# Altium schematic sheet powerRegulator.SchDoc
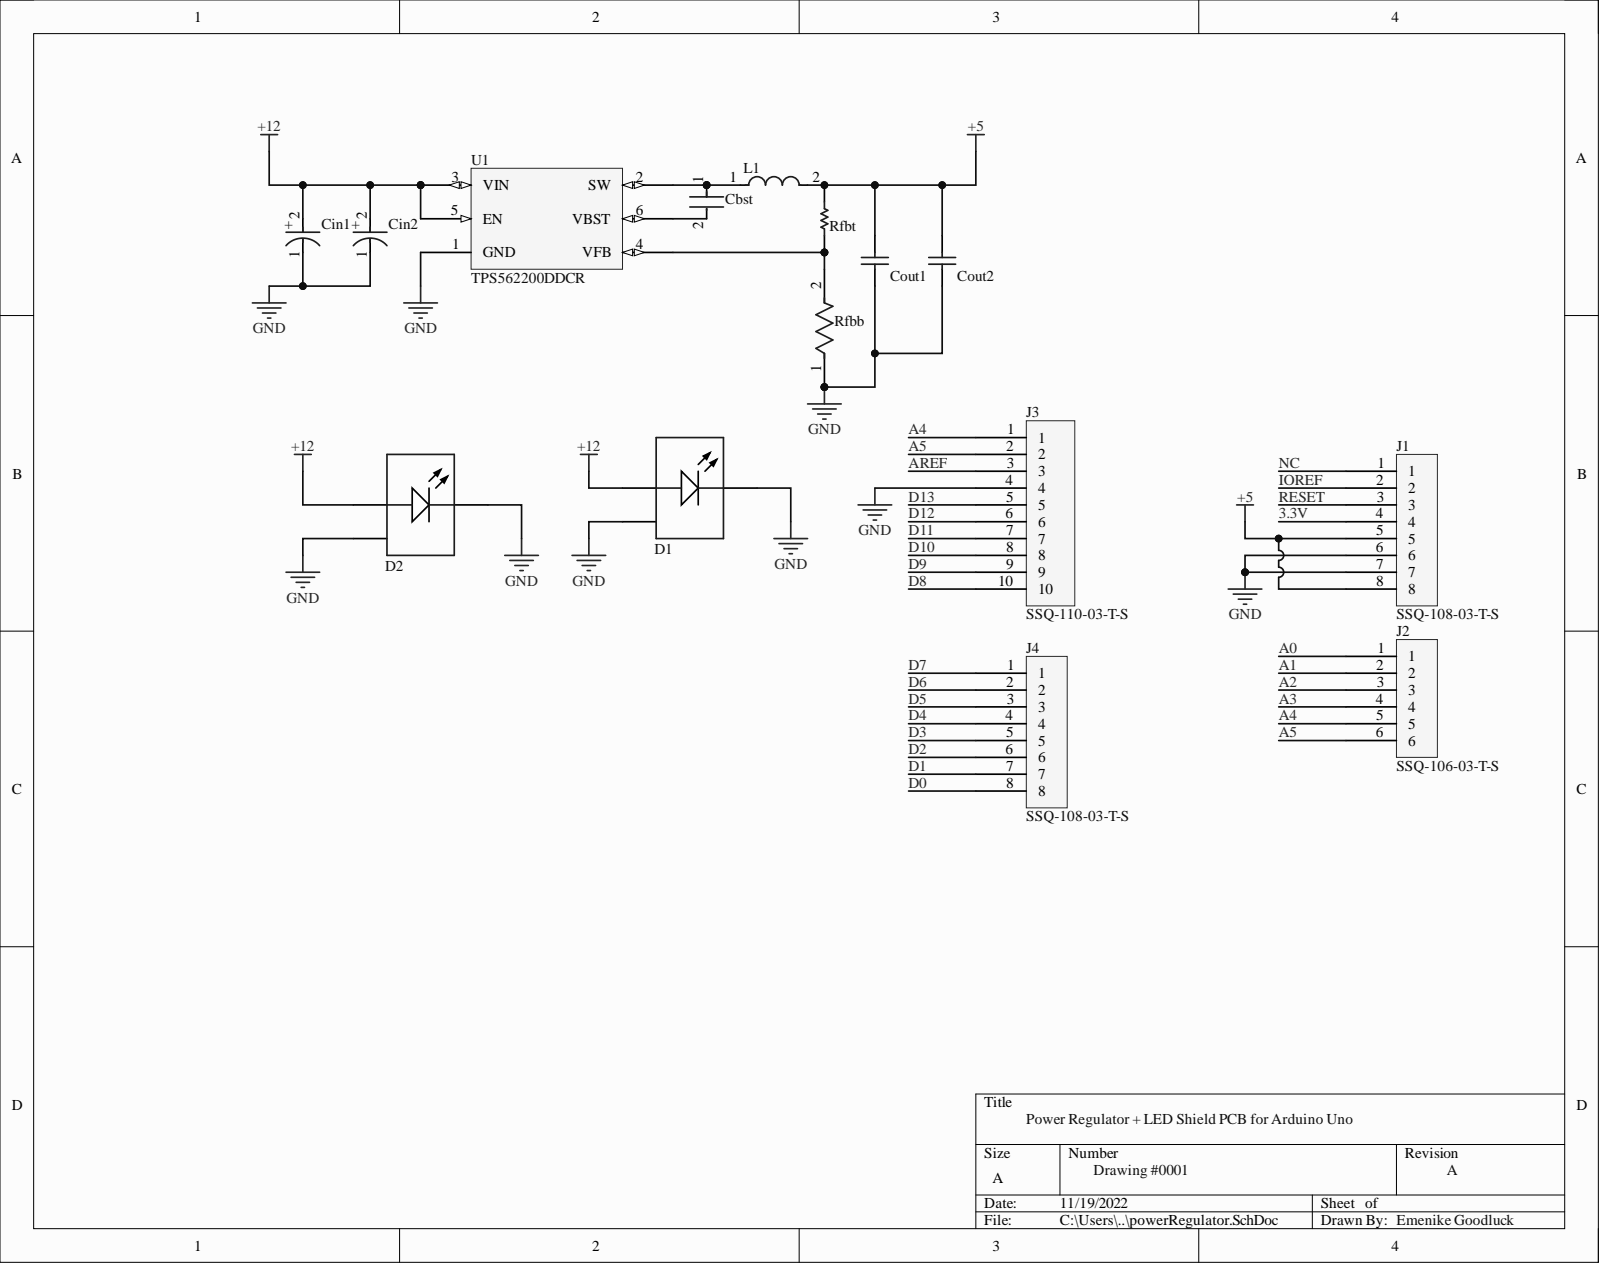
[diagram p0: powerRegulator.SchDoc]

## Parts
Cbst  CC0805KRX7R8BB104  [FP-CC0805-0_85-MFG]  (value not drawn)
Cin1  T491D106K035AT  [FP-T491D106K035AT-MFG]  (value not drawn)
Cin2  T491D106K035AT  [FP-T491D106K035AT-MFG]  (value not drawn)
Cout1  C1608X5R1A226M080AC  [CAPC1608X100X20ML10]  (value not drawn)
Cout2  C1608X5R1A226M080AC  [CAPC1608X100X20ML10]  (value not drawn)
D1  MKRAWT-00-0000-0D0HG235H  [CREE-MK-R-2_V]  (value not drawn)
D2  MKRAWT-00-0000-0D0HG235H  [CREE-MK-R-2_V]  (value not drawn)
J1  SSQ-108-03-T-S  [SSQ-108-03-T-S-Footprint-1]
J2  SSQ-106-03-T-S  [SSQ-108-03-T-S-Footprint-1]
J3  SSQ-110-03-T-S  [SSQ-108-03-T-S-Footprint-1]
J4  SSQ-108-03-T-S  [SSQ-108-03-T-S-Footprint-1]
L1  NR6028T4R7M  [FP-NR6028-MFG]  (value not drawn)
Rfbb  ERJ-1GNF1002C  [FP-ERJ1G-MFG]  (value not drawn)
Rfbt  CRCW020156K2FNED  [RESC0603X28X15NL05T05]  (value not drawn)
U1  TPS562200DDCR  [SOIC127P280X110-6N]

## Nets
+5: Cout1.2 Cout2.2 J1.5 J1.8 L1.2 Rfbt.2
+12: Cin1.2 Cin2.2 D1.1 D2.1 U1.3(VIN) U1.5(EN)
GND: Cin1.1 Cin2.1 Cout1.1 Cout2.1 D1.2 D1.3 D2.2 D2.3 J1.6 J1.7 J3.4 Rfbb.1 U1.1(GND)
3.3V: J1.4
A0: J2.1
A1: J2.2
A2: J2.3
A3: J2.4
A4: J2.5 J3.1
A5: J2.6 J3.2
AREF: J3.3
D0: J4.8
D1: J4.7
D2: J4.6
D3: J4.5
D4: J4.4
D5: J4.3
D6: J4.2
D7: J4.1
D8: J3.10
D9: J3.9
D10: J3.8
D11: J3.7
D12: J3.6
D13: J3.5
IOREF: J1.2
NC: J1.1
RESET: J1.3
NetCbst_1: Cbst.1 L1.1 U1.2(SW)
NetCbst_2: Cbst.2 U1.6(VBST)
NetRfbb_2: Rfbb.2 Rfbt.1 U1.4(VFB)

## Library data of the parts
Cbst = CMP-1036-04410-2: CC0805 100 nF X7R 10.00% 25 V; Manufacturer: Yageo; Capacitance: 100 nF; Tolerance: 0.1; Value: 100 nF; Voltage Rating: 25 V; Automotive: No; Category: Cap; Component Kind: Standard; ComponentLink2Description: Datasheet; ComponentLink2URL: http://www.yageo.com/documents/recent/UPY-GPHC_Y5V_6.3V-to-50V_8.pdf; Device Class L1: Passive Components; Device Class L2: Capacitors; Device Class L3: Ceramic Capacitors; Digikey Description: CAP CER 0.1UF 25V X7R 0805; Footprint Url: http://www.yageo.com/exep/pages/download/literatures/UPY-C_GEN_24.pdf; Height: 0.95mm; Ipc Land Pattern Name: CAPC200125X85; Lead Free: Yes; Library Name: Altium Content Vault; Manufacturer Part Number: CC0805KRX7R8BB104; Material: Ceramic; Mouser Description: Multilayer Ceramic Capacitors MLCC - SMD/SMT 100nF 25V X7R 10%; Octopart: https://octopart.com/cc0805krx7r8bb104-yageo-39467766; Package: CC0805-0.85; RoHS: TRUE; Temperature: -30°C to +85°C; Temperature Characteristic: X7R; Temperature Range High: +85°C; Temperature Range Low: -30°C
Cin1 Cin2 = CMP-2000-07580-2: CAP TANT 10UF 10% 35V 2917; Dielectric Material: Tantalum; Dissipation Factor: 6%; ESR (Equivalent Series Resistance): 1R; Leakage Current: 3.5uA; Life (Hours): 2000Hour; Polarity: Polar; Tolerance: 20%; Capacitance: 10uF; Case Code (Imperial): 2917; Case Code (Metric): 7343; Case/Package: 2917; Component Kind: Standard; Depth: 4.3mm; Features: General Purpose; Height: 2.8mm; Height - Seated (Max): 0.122inch; Lead Free: Lead Free; Length: 7.3mm; Library Name: Altium Content Vault; Max Operating Temperature: 125°C; Min Operating Temperature: -55°C; Mount: Surface Mount; Number of Pins: 2; Package Quantity: 1; Packaging: Tape and Reel; Radiation Hardening: No; REACH SVHC: No SVHC; RoHS Compliant: Yes; Termination: SMD/SMT; Voltage: 35V; Voltage Rating: 35V; Voltage Rating (DC): 35V; Width: 4.3mm
Cout1 Cout2 = CMP-2000-05441-1: Chip Capacitor, 22 uF, +/- 20%, 10 V, -55 to 85 degC, 0603 (1608 Metric), RoHS, Tape and Reel; Manufacturer: TDK; PCN Part Number: MLCCPartNumberChange30/Nov/2012; Capacitance: 22µF; Design Resources: 20WNon-IsolatedBuckLEDDriverUsingPLLNK460KG; Kits: 445-9014-KIT-ND-KITMLCC100PF-22UF060310-50V; Online Catalog: CSeries,GeneralPurpose; Other Names: 445-9077-1; Product Training Modules: CSeriesGeneralApplicationsSEAT,CCV,andTVCLDesignToolsGuidetoReplacinganElectrolyticCapacitorwithanMLCC; Temperature Coefficient: X5R; Voltage - Rated: 10V; Applications: GeneralPurpose; Category: Capacitors; Component Kind: Standard; Datasheet URL: http://download.siliconexpert.com/pdfs/2015/4/21/15/54/45/779/tdk_/manual/37024850441143360mlcc_commercial_general_en.pdf; Datasheet Version: Mar-15; Family: CeramicCapacitors; Features: -; Height - Seated (Max): -; Lead Spacing: -; Lead Style: -; Library Name: Altium Content Vault; Manufacturer URL: http://www.tdk.com/; Mounting Type: SurfaceMount,MLCC; Operating Temperature: -55degC~85degC; Package / Case: 0603(1608Metric); Package Description: 2-Pin Surface Mount Device, Body 1.6 x 0.8 mm; Package Reference: 603; Packaging: CutTape(CT); Ratings: -; RoHS Information: RoHSCertificate-MLCC; Series: C; Size / Dimension: 0.063"Lx0.031"W(1.60mmx0.80mm); Standard Package: 1; Thickness (Max): 0.035"(0.90mm); Tolerance: ±20%
D1 D2 = CMP-2100-00977-1: LED, White, 12 V, 2-Pin SMD, RoHS, Tape and Reel; Component Kind: Standard; Library Name: Altium Content Vault
J1 J4 = CMP-00003-2: Headers & Wire Housings Tiger Buy Socket Strip with Square Tails, 0.100 Pitch; Connector Type: Receptacle; Gender: Female; Number of Contacts: 8; Pitch: 0.1inch; Color: Black; Component Kind: Standard; Contact Material: Bronze; Contact Plating: Tin; Contact Type: Female Socket; Height: 8.51mm; Housing Color: Black; Housing Material: Plastic; Lead Free: Lead Free; Length: 20.8mm; Mount: Through Hole; Number of Positions: 8; Number of Rows: 1; Packaging: Bulk; Radiation Hardening: No; RoHS Compliant: Yes; Series: SSQ; Termination: Solder; Width: 2.41mm; Library Name: Carnegie Mellon University
J2 = CMP-00006-2: Headers & Wire Housings Tiger Buy Socket Strip with Square Tails, 0.100 Pitch; Connector Type: Receptacle; Gender: Female; Number of Contacts: 6; Pitch: 0.1inch; Color: Black; Component Kind: Standard; Contact Material: Bronze; Contact Plating: Tin; Contact Type: Female Socket; Height: 8.51mm; Housing Color: Black; Housing Material: Plastic; Lead Free: Lead Free; Length: 15.8mm; Mount: Through Hole; Number of Positions: 6; Number of Rows: 1; Packaging: Bulk; Radiation Hardening: No; RoHS Compliant: Yes; Series: SSQ; Termination: Solder; Width: 2.41mm; Library Name: Carnegie Mellon University
J3 = CMP-00007-1: Headers & Wire Housings Tiger Buy Socket Strip with Square Tails, 0.100 Pitch; Connector Type: Receptacle; Gender: Female; Number of Contacts: 10; Pitch: 0.1inch; Color: Black; Component Kind: Standard; Contact Material: Bronze; Contact Plating: Tin; Contact Type: Female Socket; Height: 8.51mm; Housing Color: Black; Housing Material: Plastic; Lead Free: Lead Free; Length: 25.9mm; Mount: Through Hole; Number of Positions: 10; Number of Rows: 1; Package Quantity: 1; Packaging: Bulk; Radiation Hardening: No; RoHS Compliant: Yes; Series: SSQ; Termination: Solder; Width: 2.41mm; Library Name: Carnegie Mellon University
L1 = CMP-14484-000037-2: SMD Power Inductors 4.7uH ±20% 2.7A 40.3mΩ; Core Material: Ferrite; Current Rating: 3A; DC Resistance (DCR): 40.3mR; Self Resonant Frequency: 39MHz; Shielding: Shielded; Test Frequency: 100kHz; Case/Package: 2424; Component Kind: Standard; Depth: 6.2mm; Halogen Free: Halogen Free; Height: 2.8mm; Height - Seated (Max): 0.11inch; Inductance: 4.7uH; Inductance Tolerance: 20%; Lead Free: Lead Free; Length: 0.236inch; Library Name: Altium Content Vault; Max DC Current: 3A; Max Operating Temperature: 120°C; Min Operating Temperature: -25°C; Mount: Surface Mount; Number of Pins: 2; Package Quantity: 2000; Packaging: Tape and Reel; Radiation Hardening: No; Resistor Type: Wirewound; RMS Current (Irms): 3A; RoHS Compliant: Yes; Saturation Current: 2.7A; Series: NR; Termination: SMD/SMT; Tolerance: 20%; Width: 0.236inch
Rfbb = CMP-2004-03445-2: 10 kOhms ±1% 0.05W, 1/20W Chip Resistor 0201 (0603 Metric) Automotive AEC-Q200 Thick Film; Manufacturer: Panasonic Electronic Components; Automotive Grade: Grade 1; Resistance: 10kΩ; Temperature Coefficient: 200ppm/°C; Value: 10kΩ; Voltage Rating: 25V; Automotive: Yes; Category: Res; Component Kind: Standard; ComponentLink2Description: Datasheet; ComponentLink2URL: https://industrial.panasonic.com/cdbs/www-data/pdf/RDA0000/AOA0000C304.pdf; Composition: Thick Film; Device Class L1: Passive Components; Device Class L2: Resistors; Device Class L3: Chip SMD Resistors; Digikey Description: RES SMD 10K OHM 1% 1/20W 0201; Footprint Url: https://industrial.panasonic.com/cdbs/www-data/pdf/RDA0000/DMA0000COL17.pdf; Height: 0.26mm; Lead Free: Yes; Library Name: Altium Content Vault; Manufacturer Part Number: ERJ-1GNF1002C; Mouser Description: Thick Film Resistors - SMD 0201 10Kohm 1% HalogenFree AEC-Q200; Octopart: https://octopart.com/erj-1gnf1002c-panasonic-55419697; Package: ERJ1G; Power Rating: 0.05W; RoHS: TRUE; Series: ERJ; Temperature: -55°C to +125°C; Temperature Range High: +125°C; Temperature Range Low: -55°C; Tolerance: 1%
Rfbt = CMP-2004-00723-1: Manufacturer: Vishay Dale; Component Kind: Standard; Library Name: Altium Content Vault; Part Number: CRCW020156K2FNED
U1 = CMP-00005-3: Buck Switching Regulator IC Positive Adjustable 0.765V 1 Output 2A SOT-23-6 Thin, TSOT-23-6; Max Input Voltage: 17V; Max Output Voltage: 7V; Min Input Voltage: 4.5V; Min Output Voltage: 765mV; Number of Outputs: 1; Quiescent Current: 230uA; Switching Frequency: 650kHz; Synchronous Rectifier: Yes; Case/Package: SOT-23-6; Component Kind: Standard; Contact Plating: Tin; Lead Free: Lead Free; Max Operating Temperature: 125°C; Max Output Current: 2A; Min Operating Temperature: -40°C; Mount: Surface Mount; Number of Pins: 6; Operating Supply Voltage: 17V; Output Configuration: Positive; Output Current: 2A; Output Type: Adjustable; Output Voltage: 7V; Package Quantity: 3000; Packaging: Tape and Reel; Radiation Hardening: No; Reach SVHC Compliant: Yes; RoHS Compliant: Yes; Series: D-CAP2™, Eco-Mode™; Weight: 0.00127oz; Library Name: Carnegie Mellon University
